# Altium schematic sheet Motor-E1.SchDoc(Motor-E1)
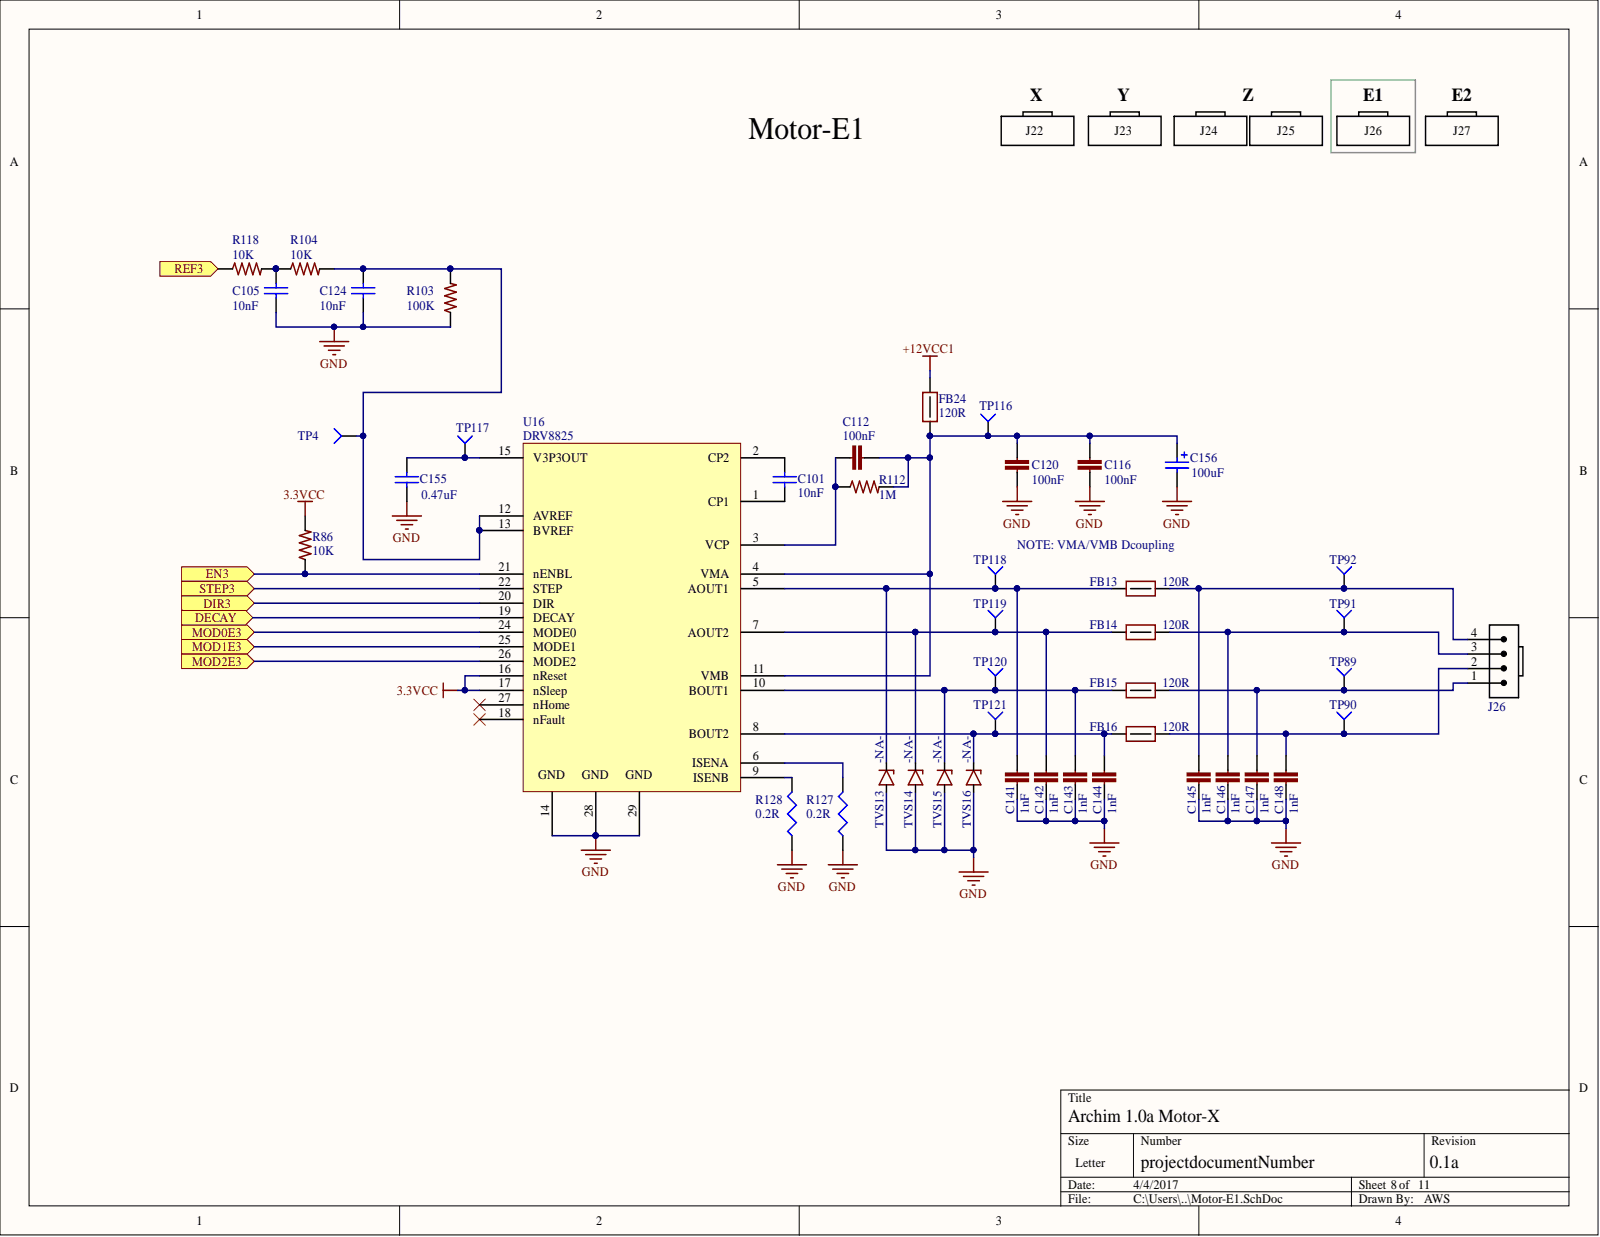
[diagram p0: Motor-E1.SchDoc]

## Parts
C101  10nF  [C0402]
C105  10nF  [C0402]
C112  100nF  [C0402]
C116  100nF  [C0603]
C120  100nF  [C0603]
C124  10nF  [C0402]
C141  1nF  [C0402]
C142  1nF  [C0402]
C143  1nF  [C0402]
C144  1nF  [C0402]
C145  1nF  [C0402]
C146  1nF  [C0402]
C147  1nF  [C0402]
C148  1nF  [C0402]
C155  value 0.47uF  [C0402]  (hidden comment: 0402)
C156  value 100uF  [CAP-ELEC-063063077]  (hidden comment: UWT1V101MCL1GS)
FB13  120R  [FB0603]
FB14  120R  [FB0603]
FB15  120R  [FB0603]
FB16  120R  [FB0603]
FB24  120R  [FB0603]
J26  Molex 0705430003  [4POL254]  (value not drawn)
R86  10K  [R0402]
R103  100K  [R0402]
R104  10K  [R0402]
R112  1M  [R0402]
R118  10K  [R0402]
R127  value 0.2R  [2512R-0.55]  (hidden comment: 2512)
R128  value 0.2R  [2512R-0.55]  (hidden comment: 2512)
TP4  TP4  [TP-2]  (no BOM)
TP89  TP4  [TP-2]  (value not drawn)  (no BOM)
TP90  TP4  [TP-2]  (value not drawn)  (no BOM)
TP91  TP4  [TP-2]  (value not drawn)  (no BOM)
TP92  TP4  [TP-2]  (value not drawn)  (no BOM)
TP116  TP4  [TP-2]  (value not drawn)  (no BOM)
TP117  TP4  [TP-2]  (value not drawn)  (no BOM)
TP118  TP4  [TP-2]  (value not drawn)  (no BOM)
TP119  TP4  [TP-2]  (value not drawn)  (no BOM)
TP120  TP4  [TP-2]  (value not drawn)  (no BOM)
TP121  TP4  [TP-2]  (value not drawn)  (no BOM)
TVS13  value -NA-  [SMF]  (hidden comment: TVS 30V SMF)
TVS14  value -NA-  [SMF]  (hidden comment: TVS 30V SMF)
TVS15  value -NA-  [SMF]  (hidden comment: TVS 30V SMF)
TVS16  value -NA-  [SMF]  (hidden comment: TVS 30V SMF)
U16  DRV8825  [DRV8825PWPR]

## Ports
DECAY, DIR3, EN3, MOD0E3, MOD1E3, MOD2E3, REF3, STEP3

## Nets
3.3VCC: R86.2 U16.16(nReset) U16.17(nSleep)
+12VCC1: FB24.2
GND: C105.1 C116.2 C120.2 C124.1 C141.2 C142.2 C143.2 C144.2 C145.2 C146.2 C147.2 C148.2 C155.1 C156.2 R103.1 R127.1 R128.1 TVS13.A TVS14.A TVS15.A TVS16.A U16.14 U16.28 U16.29
DECAY: U16.19(DECAY) ; port DECAY
DIR3: U16.20(DIR) ; port DIR3
EN3: R86.1 U16.21(nENBL) ; port EN3
MOD0E3: U16.24(MODE0) ; port MOD0E3
MOD1E3: U16.25(MODE1) ; port MOD1E3
MOD2E3: U16.26(MODE2) ; port MOD2E3
REF3: R118.1 ; port REF3
STEP3: U16.22(STEP) ; port STEP3
NetC101_1: C101.1 U16.1(CP1)
NetC101_2: C101.2 U16.2(CP2)
NetC105_2: C105.2 R104.1 R118.2
NetC112_1: C112.1 R112.1 U16.3(VCP)
NetC112_2: C112.2 C116.1 C120.1 C156.1 FB24.1 R112.2 TP116.1 U16.4(VMA) U16.11(VMB)
NetC124_2: C124.2 R103.2 R104.2 TP4.1 U16.12(AVREF) U16.13(BVREF)
NetC141_1: C141.1 FB13.1 TP118.1 TVS13.K U16.5(AOUT1)
NetC142_1: C142.1 FB14.1 TP119.1 TVS14.K U16.7(AOUT2)
NetC143_1: C143.1 FB15.1 TP120.1 TVS15.K U16.10(BOUT1)
NetC144_1: C144.1 FB16.1 TP121.1 TVS16.K U16.8(BOUT2)
NetC145_1: C145.1 FB13.2 J26.4 TP92.1
NetC146_1: C146.1 FB14.2 J26.3 TP91.1
NetC147_1: C147.1 FB15.2 J26.1 TP89.1
NetC148_1: C148.1 FB16.2 J26.2 TP90.1
NetC155_2: C155.2 TP117.1 U16.15(V3P3OUT)
NetR127_2: R127.2 U16.6(ISENA)
NetR128_2: R128.2 U16.9(ISENB)

Not connected: U16 18(nFault) 27(nHome)

## Library data of the parts
C101 C105 C124 = GRM155R71H103JA88D: CAP CER 10nF 50V X7R 0402; PART DESCRIPTION: 10000pF ±5% 50V Ceramic Capacitor X7R 0402 (1005 Metric); MANUFACTURER: Murata; TOLERANCE: 5%; VALUE: 10nF; Simulation: CAP; Signal Integrity: Cap Semi; PART: 10nF 50V X7R 0402; MANUFACTURER #: GRM155R71H103JA88D; Library Name: Tigershark3D.SchLib; EE_SPEC: 50V; DISTRIBUTOR #: 490-7763-1-ND; DISTRIBUTOR: Digikey; Component Kind: Standard
C112 = GRM155R71C104KA88D: CAP CER 0402 100nF 16V 10% X7R; PART DESCRIPTION: 0.10µF ±10% 16V Ceramic Capacitor X7R 0402 (1005 Metric); PART: 0.1uF 16V X7R 0402; MANUFACTURER: Murata; VALUE: 100nF; TOLERANCE: 10%; Simulation: Model Name; Signal Integrity: Model Name; MANUFACTURER #: GRM155R71C104KA88D; Library Name: 3Delight.SCHLIB; EE_SPEC: 16V; DISTRIBUTOR #: 490-3261-2-ND; DISTRIBUTOR: Digikey; Component Kind: Standard
C116 C120 = C0603C104K5RACTU: CAP CER 0603 100nF 50V 10% X7R; PART DESCRIPTION: 0.10µF ±10% 50V Ceramic Capacitor X7R 0603 (1608 Metric); PART: 100nF 50V X7R 0603; MANUFACTURER: Kemet; VALUE: 100nF; TOLERANCE: 10%; Simulation: Model Name; Signal Integrity: Model Name; MANUFACTURER #: C0603C104K5RACTU; Library Name: 3Delight.SCHLIB; EE_SPEC: 50V; DISTRIBUTOR #: 399-5089-2-ND; DISTRIBUTOR: Digikey; Component Kind: Standard
C141-C148 = CAP 1nF 50V 0402 X7R: 1000pF 50V Ceramic Capacitor X7R 0402 (1005 Metric) 0.039" L x 0.020" W (1.00mm x 0.50mm); PART DESCRIPTION: 1000pF ±10% 50V Ceramic Capacitor X7R 0402 (1005 Metric); PART: 1nF 50V X7R 0402; MANUFACTURER #: GRM155R71H102KA01D; MANUFACTURER: Murata; TOLERANCE: 10%; VALUE: 1nF; Library Name: 3Delight.SCHLIB; EE_SPEC: 50V; DISTRIBUTOR #: 490-1303-2-ND; DISTRIBUTOR: Digikey; Component Kind: Standard
C155 = GRM155R60J474KE19D: 0.47uF 6.3v C0402 - GRM155R60J474KE19D; PART DESCRIPTION: 0.47µF ±10% 6.3V Ceramic Capacitor X5R 0402 (1005 Metric); PART: 0.47uF 6.3V X5R 0402; MANUFACTURER: Murata; TOLERANCE: 10%; Simulation: CAP; Signal Integrity: Cap Semi; MANUFACTURER #: GRM155R60J474KE19D; Library Name: Tigershark3D.SchLib; EE_SPEC: 6.3V; DISTRIBUTOR #: 490-3266-2-ND; DISTRIBUTOR: Digikey; Component Kind: Standard
C156 = UWT1V101MCL1GS: CAP ALUM 100UF 35V 20% SMD; PART DESCRIPTION: 100µF 35V Aluminum Capacitors Radial, Can - SMD 1000 Hrs @ 105°C; PART: 100uF 35V SMD Al-El; MANUFACTURER: Nichicon; TOLERANCE: 20%; Simulation: CAP; Signal Integrity: Cap Pol; MANUFACTURER #: UWT1V101MCL1GS; Library Name: 3Delight.SCHLIB; EE_SPEC: 35V; DISTRIBUTOR #: 493-2203-2-ND; DISTRIBUTOR: Digikey; Component Kind: Standard
FB13-FB16 FB24 = FERRITE 120R 3A 0603: FERRITE BEAD 120 OHM 0603 1LN; MANUFACTURER #: CIS10P121AC; MANUFACTURER2#: 490-5254-2-ND; MANUFACTURER: Samsung; VALUE: 120Rfb; TOLERANCE: -NA-; EE_SPEC: 3A; PART DESCRIPTION: FERRITE BEAD 120 OHM 0603 1LN; PART: FERRITE 120R 3A 0603; Library Name: 3Delight.SCHLIB; DISTRIBUTOR #: 1276-6351-2-ND; DISTRIBUTOR: Digikey; Component Kind: Standard
J26 = Molex 0705430003 Alt_1: Molex 4 Pin Clip Connector; PART DESCRIPTION: 4 Positions Header, Shrouded Connector 0.100" (2.54mm) Through Hole Tin; PART: 4 Pin Conn; MANUFACTURER #: 0705430038; VALUE: HDR4; TOLERANCE: -NA-; MANUFACTURER: Molex; EE_SPEC: -NA-; DISTRIBUTOR #: WM4826-ND; DISTRIBUTOR: Digikey; Component Kind: Standard; Library Name: Archim.SCHLIB
R86 R104 R118 = RC0402FR-0710KP: RES SMD 10K OHM 1% 1/16W 0402; PART DESCRIPTION: 10k Ohm ±1% 0.063W, 1/16W Chip Resistor 0402 (1005 Metric) Moisture Resistant Thick Film; PART: 10k 1% 0402; MANUFACTURER: Yageo; VALUE: 10K; TOLERANCE: 1%; Simulation: Model Name; Signal Integrity: Model Name; MANUFACTURER #: RC0402FR-0710KP; Library Name: 3Delight.SCHLIB; EE_SPEC: 1/16W; DISTRIBUTOR #: YAG1249TR-ND; DISTRIBUTOR: Digikey; Component Kind: Standard
R103 = RC1005F104CS: RES SMD 100K OHM 1% 1/16W 0402; PART DESCRIPTION: 100k Ohm ±1% 0.063W, 1/16W Chip Resistor 0402 (1005 Metric) Moisture Resistant Thick Film; PART: 100k 1% 0402; MANUFACTURER: Samsung; VALUE: 100K; TOLERANCE: 1%; Simulation: Model Name; Signal Integrity: Model Name; MANUFACTURER #: RC1005F104CS; Library Name: Tigershark3D.SchLib; EE_SPEC: 1/16W; DISTRIBUTOR #: 1276-3432-1-ND; DISTRIBUTOR: Digikey; Component Kind: Standard
R112 = ERJ2GEJ105X: RES 0402 1M 100mW 5%; PART DESCRIPTION: 1M Ohm ±5% 0.1W, 1/10W Chip Resistor 0402 (1005 Metric) Automotive AEC-Q200 Thick Film; PART: 1M 5% 0402; MANUFACTURER: Panasonic; EE_SPEC: 1/10W; VALUE: 1M; TOLERANCE: 5%; Simulation: Model Name; Signal Integrity: Model Name; MANUFACTURER #: ERJ2GEJ105X; Library Name: Tigershark3D.SchLib; DISTRIBUTOR #: P1.0MJTR-ND; DISTRIBUTOR: Digikey; Component Kind: Standard
R127 R128 = CSRN2512FTR200: RES SMD 0.2 OHM 1% 2W 2512; PART DESCRIPTION: 0.2 Ohm ±1% 2W Chip Resistor 2512 (6432 Metric) Current Sense Thick Film; PART: 0.2R 1% 2512; MANUFACTURER #: CSRN2512FKR200; MANUFACTURER: Stackpole ELectronics Inc; TOLERANCE: 1%; Simulation: RESISTOR; Signal Integrity: Res; EE_SPEC: 2W; DISTRIBUTOR #: CSRN2512FKR200TR-ND; DISTRIBUTOR: Digikey; Component Kind: Standard; Library Name: Archim.SCHLIB
TP4 TP89-TP92 TP116-TP121 = Socket: MANUFACTURER #: NOTAPART-Testpoint; Signal Integrity: Connector; Description: Socket; Library Name: Archim.SCHLIB
TVS13-TVS16 = TVS 30V SMF: TVS DIODE 30VWM 48.4VC SMF; PART: TVS Diode 48.4VC; MANUFACTURER #: SMF30A-E3-08; MANUFACTURER: Vishay; EE_SPEC: 30Vrs 48.4Vc; TOLERANCE: -NA-; PART DESCRIPTION: TVS DIODE 30VWM 48.4VC SMF; Library Name: 3Delight.SCHLIB; DISTRIBUTOR #: SMF30A-E3-08GITR-ND; DISTRIBUTOR: Digikey; Component Kind: Standard
U16 = DRV8825: DRV8825 Ti Stepper Driver; PART DESCRIPTION: Bipolar Motor Driver Power MOSFET Step/Direction 28-HTSSOP; MANUFACTURER: Texas Instruments; VALUE: -NA-; EE_SPEC: 8.2-45VDC; TOLERANCE: -NA-; PART: DRV8825; MANUFACTURER #: DRV8825PWPR; Library Name: Tigershark3D.SchLib; DISTRIBUTOR #: 296-29503-2-ND; DISTRIBUTOR: Digikey; Component Kind: Standard
